# Altium schematic sheet Main.SchDoc
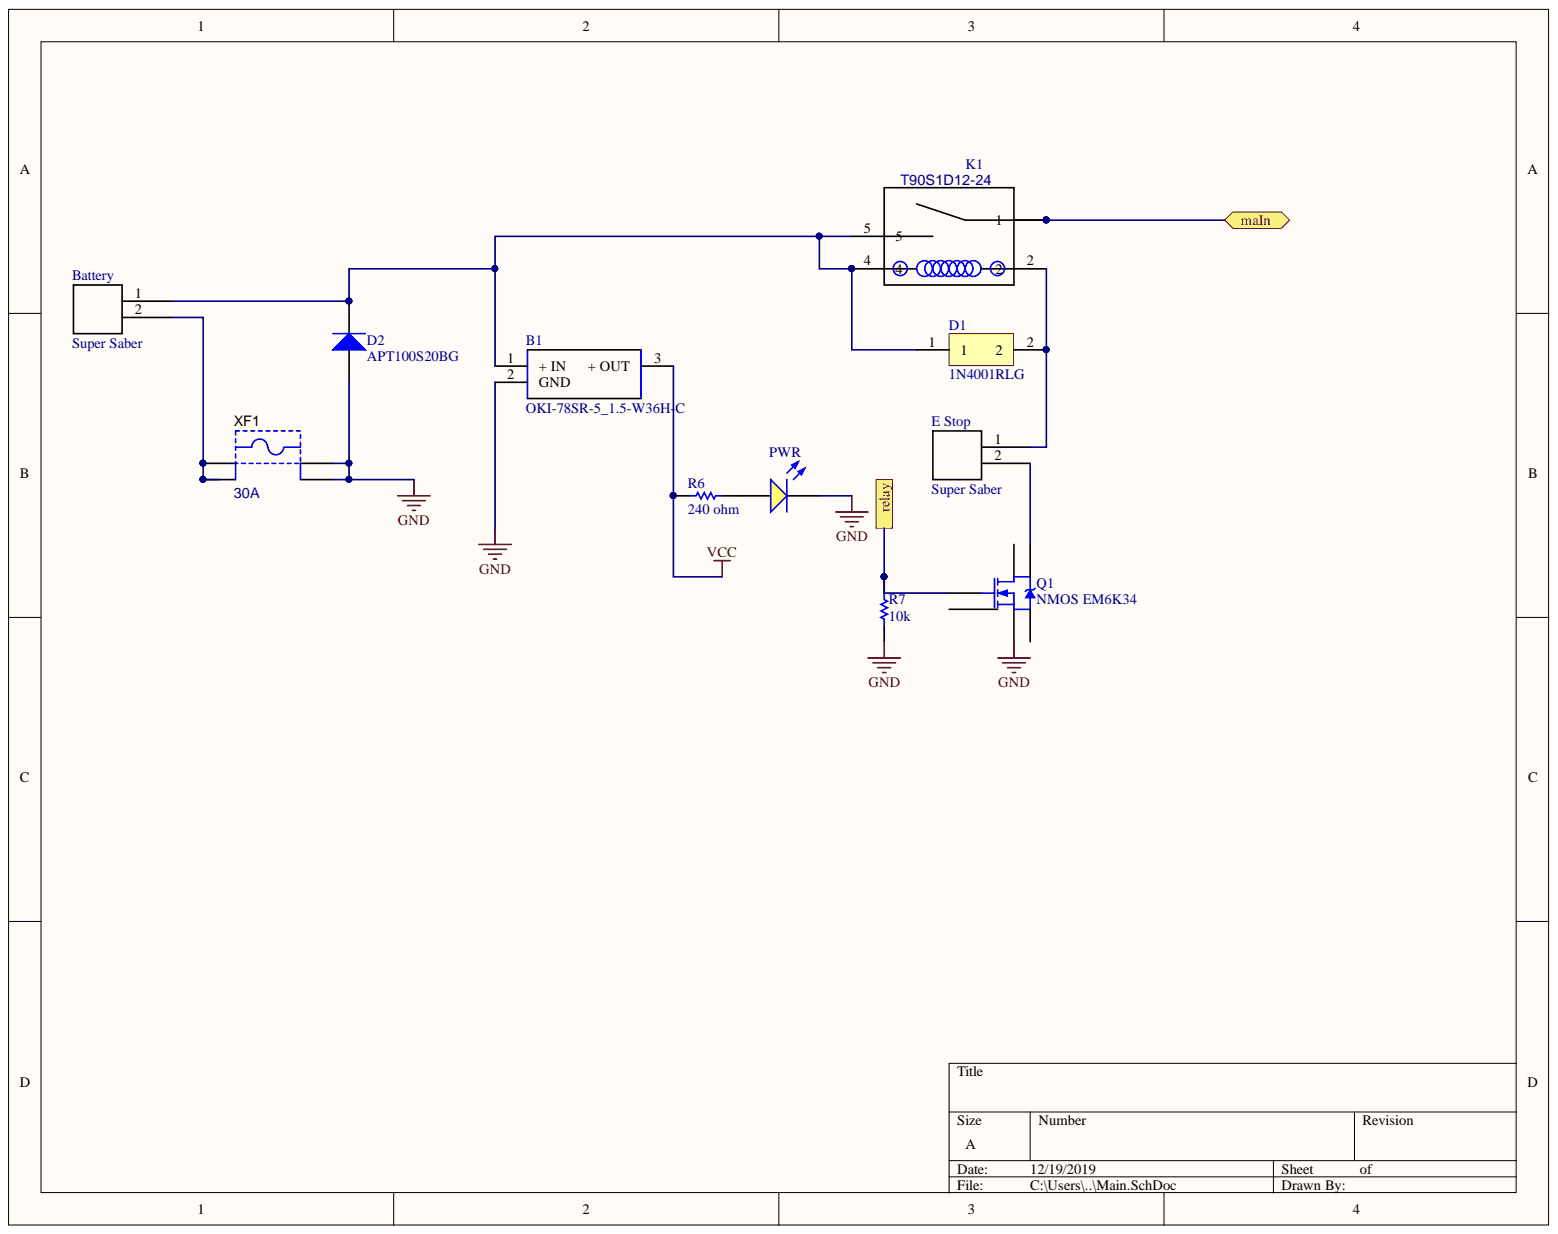
[diagram p0: Main.SchDoc]

## Parts
B1  OKI-78SR-5_1.5-W36H-C  [OKI-78SR-HORIZONTAL]
Battery  Super Saber  [PCBComponent_1]
D1  1N4001RLG  [ONSC-AXIAL_LEAD-2-59-10_P]
D2  APT100S20BG  [TO247-2L]
E_Stop  Super Saber  [PCBComponent_1]
K1  T90S1D12-24  [TE_1-1393209-6]
PWR  (no value)  [LTST-C170YKT_V]
Q1  NMOS EM6K34  [SOT50P160X45-6N]
R6  240 ohm  [RESC0805(2012)_N]
R7  10k  [RESC0805(2012)_N]
XF1  30A  [KSTN-3568_V]

## Ports
maIn, relay

## Nets
GND: B1.2 D2.A PWR.C Q1.4 R7.1 XF1.3 XF1.4
VCC: B1.3 R6.2
maIn: K1.1 K1.3 ; port maIn
relay: Q1.5 R7.2 ; port relay
NetB1_1: B1.1 Battery.1 D1.1 D2.K K1.4 K1.5
NetBattery_2: Battery.2 XF1.1 XF1.2
NetD1_2: D1.2 E_Stop.1 K1.2
NetE Stop_2: E_Stop.2 Q1.3
NetPWR_A: PWR.A R6.1

Not connected: Q1 1 2 6

## Library data of the parts
B1 = OKI-78SR-5_1.5-W36H-C: Switching Regulator 7-36Vin 5Vo 1.5A Non-Isolated DC-DC Converter, 5V dc Output, 1.5A; Manufacturer_Name: Murata Electronics; Manufacturer_Part_Number: OKI-78SR-5/1.5-W36H-C; Component Kind: Standard; ComponentLink1Description: datasheet; ComponentLink1URL: https://power.murata.com/pub/data/power/oki-78sr.pdf; Datasheet Link: https://componentsearchengine.com/Datasheets/1/OKI-78SR-5_1.5-W36H-C.pdf; Library Name: OKI-78SR-5_1.5-W36H-C.SchLib; Mouser Part Number: 580-OKI78SR51.5W36HC
Battery = Molex 6.5MM  power connector: Component Kind: Standard; Library Name: Connector Schematic Symbols.SchLib
D1 = CMP-0902-00204-1: Standard Recovery Rectifier, 2-Pin Axial_Lead, Pb-Free, Tape and Reel; Manufacturer: On Semiconductor; IFSM Max (A): 30; IO(rec) Max (A): 1; VRRM Max (V): 50; Component Kind: Standard; ComponentLink1Description: Manufacturer URL; ComponentLink1URL: http://www.onsemi.com/; ComponentLink2Description: Datasheet; ComponentLink2URL: http://www.onsemi.com/pub_link/Collateral/1N4001-D.PDF; ComponentLink3Description: Package Specification; ComponentLink3URL: http://www.onsemi.cn/pub_link/Collateral/59-10.PDF; DatasheetVersion: Rev. 13; Library Name: Altium Content Vault; Mounting Technology: Through Hole; PackageDescription: 2-Pin, Axial Lead, Diameter 2.35 mm; PackageReference: AXIAL_LEAD-2-59-10; PackageVersion: Rev. U, 02/2005; Packing: Tape and Reel; PartNumber: 1N4001RLG; RoHS: TRUE; Type: Standard Recovery
D2 = Diode: Default Diode; Code_JEDEC: DO-214-AB; Component Kind: Standard; ComponentLink1Description: datasheet; LatestRevisionDate: 17-Jul-2002; LatestRevisionNote: Re-released for DXP Platform.; Library Name: Miscellaneous Devices.IntLib; PackageDescription: DO-214-AB/SMC; 2 C-Bend Leads; Body 7.9 x 5.9 mm, inc. leads (LxW); PackageReference: SMC; PackageVersion: Sep-1996; Published: 8-Jun-2000; Signal Integrity: Diode; Simulation: DIODE; ComponentLink1URL: file:///C:/Users/Me/Downloads/Microsemi_APT100S20BG_High-Voltage_Schottky_Diode_Datasheet_D%20(1).PDF; Publisher: Altium Limited
K1 = 1-1393209-6: MF: TE Connectivity; Availability: Unavailable; Component Kind: Standard; ComponentLink1Description: datasheet; ComponentLink1URL: https://media.digikey.com/pdf/Data%20Sheets/Tyco%20Electronics%20P%20B%20PDFs/T90_Rev0214.pdf; Description: T90S1D12-24; Library Name: 1-1393209-6.IntLib; MP: T90S1D12-24; Package: None; Price: None; SnapEDA_Link: https://www.snapeda.com/parts/T90S1D12-24/TE%20Connectivity%20Potter%20%26%20Brumfield%20Relays/view-part/?ref=snap; TE_purchase_URL: https://www.te.com/usa-en/product-1-1393209-6.html?te_bu=Cor&te_type=disp&te_campaign=seda_glo_cor-seda-global-disp-prtnr-fy19-seda-model-bom-cta_sma-317_1&elqCampaignId=32493
PWR = CMP-1674-00003-3: LED YELLOW CLEAR 0805 SMD; Manufacturer: Lite-On; PartNumber: LTST-C170YKT; Component Kind: Standard; ComponentLink1Description: Datasheet; ComponentLink1URL: http://media.digikey.com/pdf/Data Sheets/Lite-On PDFs/LTST-C170YKT.pdf; Library Name: Altium Content Vault; PackageReference: C170YKT
Q1 = NMOS-2: N-Channel Power MOSFET; Note: Pin 2 is electrically connected to pin 4.; Component Kind: Standard; ComponentLink2Description: datasheet; ComponentLink2URL: http://rohmfs.rohm.com/en/products/databook/datasheet/discrete/transistor/mosfet/em6k34.pdf; ComponentLink3Description: info; ComponentLink3URL: https://www.electronics-tutorials.ws/blog/relay-switch-circuit.html; DatasheetVersion: PD-9.814; LatestRevisionDate: 17-Jul-2002; LatestRevisionNote: Re-released for DXP Platform.; Library Name: Miscellaneous Devices.IntLib; PackageDescription: TO, Thru-Hole, Vertical, Heatsink Mounted; 3 In-Line Leads; Pitch 2.54 mm; PackageReference: TO-220AB; PackageVersion: Feb-1997; Published: 8-Jun-2000; Simulation: IRF1010; Publisher: Altium Limited
R6 R7 = CMP-1013-00418-1: 160R 0.125W 1% 0805 (2012 Metric)  SMD; Case-EIA: 0805; Case-Metric: 2012; Value: 160.00 Ohm; Component Kind: Standard; Library Name: Altium Content Vault; Power: 0.125 W; Technology: SMT; Tolerance: 1 %
XF1 = CMP-2000-05464-1: Fuse Holder, 30; Manufacturer: Keystone; Component Kind: Standard; ComponentLink2Description: Holder; ComponentLink2URL: https://www.digikey.com/product-detail/en/keystone-electronics/3568/36-3568-ND/2137306; ComponentLink3Description: Fuse; ComponentLink3URL: https://www.digikey.com/product-detail/en/littelfuse-inc/0997030.WXN/F1878-ND/701063; Datasheet URL: https://datasheet.ciiva.com/16294/m60-2p40-16294706.pdf; Datasheet Version: 04/2013; Library Name: Altium Content Vault; Manufacturer URL: http://www.keyelco.com/; Mounting Technology: ThroughHole; Package Description: 4-Pin Through Hole Device, Body 16 x 6.73 mm, Pitch 9.9 mm; Package Reference: 3568
E_Stop = Super Saber: Component Kind: Standard; Library Name: Connector Schematic Symbols.SchLib
